# Altium schematic sheet DVT-Levelshifert-HT.SchDoc(DVT-Levelshifert-HT)
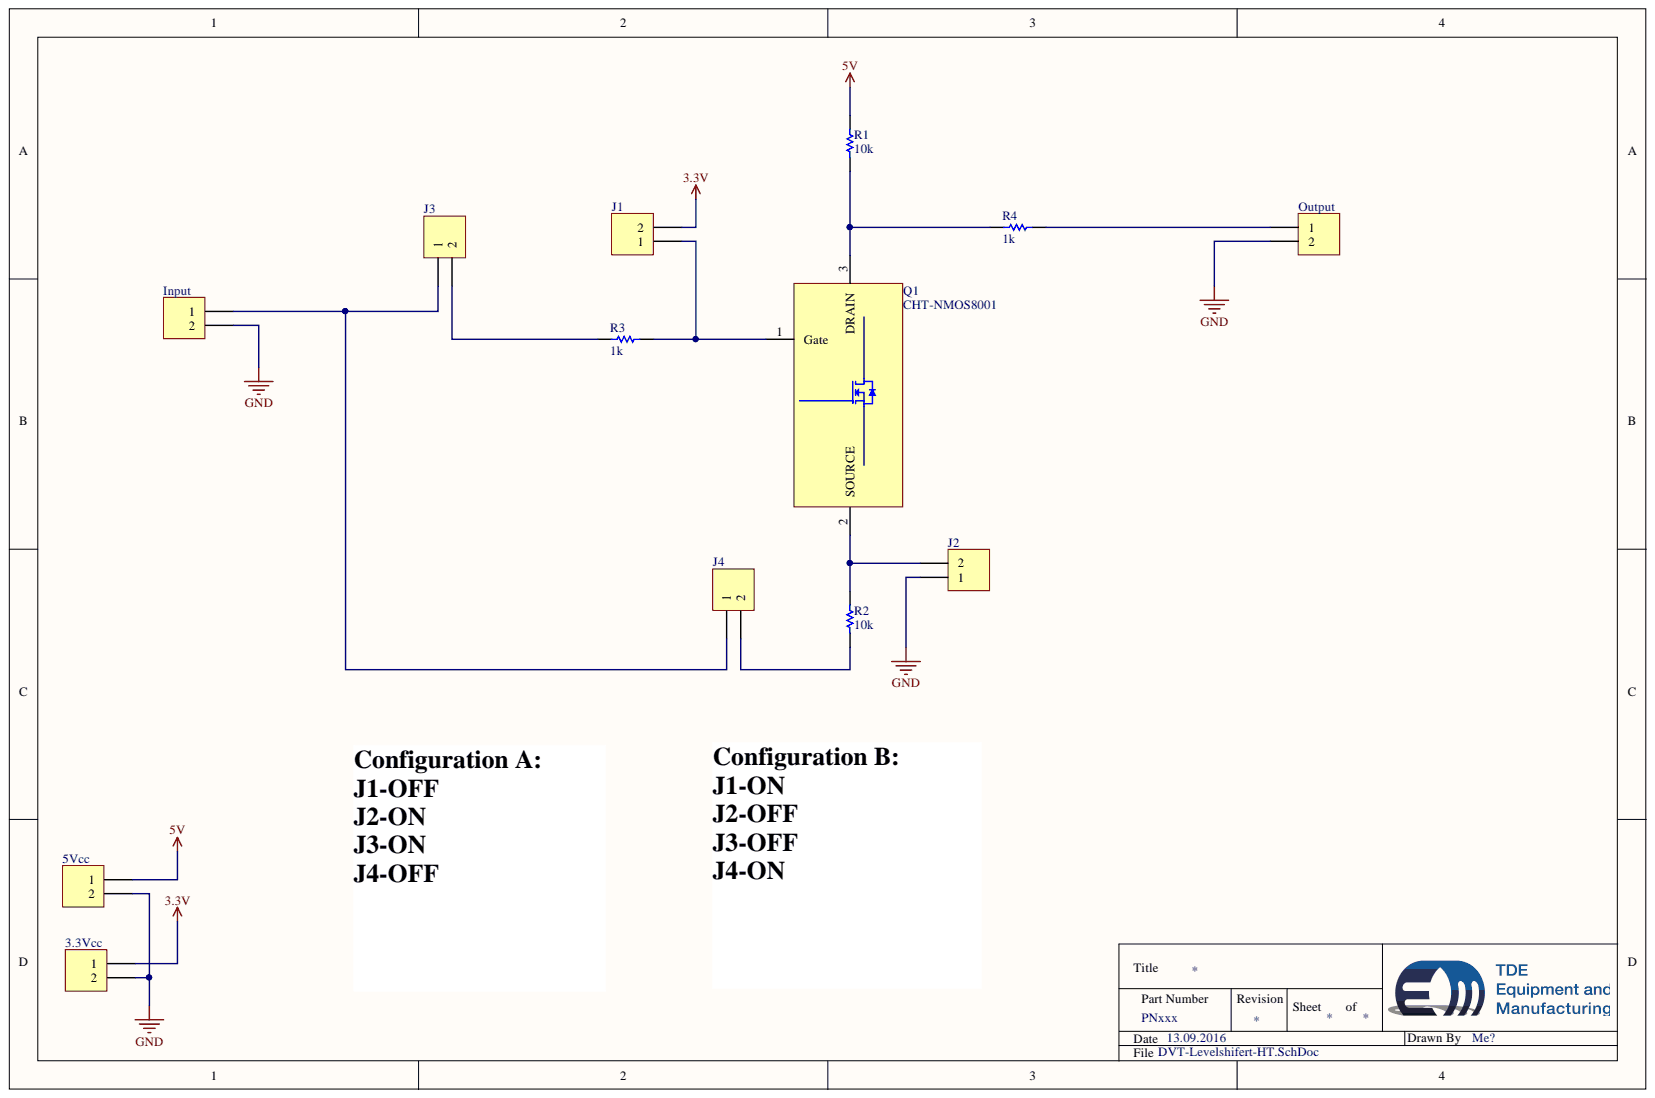
[diagram p0: DVT-Levelshifert-HT.SchDoc]

## Parts
3.3Vcc  PHOENIX_1715721  [Phoenix-2-5_08]  (value not drawn)
5Vcc  PHOENIX_1715721  [Phoenix-2-5_08]  (value not drawn)
Input  PHOENIX_1715721  [Phoenix-2-5_08]  (value not drawn)
J1  PHOENIX_1715721  [HDR1X2]  (value not drawn)
J2  PHOENIX_1715721  [HDR1X2]  (value not drawn)
J3  PHOENIX_1715721  [HDR1X2]  (value not drawn)
J4  PHOENIX_1715721  [HDR1X2]  (value not drawn)
Output  PHOENIX_1715721  [Phoenix-2-5_08]  (value not drawn)
Q1  CHT-NMOS8001  [TDFP16 - NMOS8001]
R1  value 10k  [Dummy-10mm]  (hidden comment: Resistor-Dummy-THT)
R2  value 10k  [Dummy-10mm]  (hidden comment: Resistor-Dummy-THT)
R3  value 1k  [Dummy-10mm]  (hidden comment: Resistor-Dummy-THT)
R4  value 1k  [Dummy-10mm]  (hidden comment: Resistor-Dummy-THT)

## Nets
3.3V: 3.3Vcc.1 J1.2
5V: 5Vcc.1 R1.2
GND: 3.3Vcc.2 5Vcc.2 Input.2 J2.1 Output.2
NetInput_1: Input.1 J3.1 J4.1
NetJ1_1: J1.1 Q1.1 R3.1
NetJ2_2: J2.2 Q1.2 R2.2
NetJ3_2: J3.2 R3.2
NetJ4_2: J4.2 R2.1
NetOutput_1: Output.1 R4.1
NetQ1_3: Q1.3 R1.1 R4.2

## Library data of the parts
3.3Vcc 5Vcc Input Output = 2Pol_1715721: Phoenix, 2 pol, 5.08mm, 22A, 715721; Deliverer PartNr: 193-0564; Deliverer: RS; TDE-PN: CON0008; Component Kind: Standard; LatestRevisionDate: 02.05.2014; LatestRevisionNote: Re-released for DXP Platform.; Library Name: Connectors.IntLib; Manufacturer: Phoenix; Manufacturer PartNr: 1715721; PackageDescription: Phoenix-2-5_08; Price: 0.976/5; Signal Integrity: Connector; Publisher: Sebastian Kulik
J1-J4 = Plug_2_90_2.54: Plug, 2-Pin; Deliverer PartNr: 2356176; Manufacturer: WE; Manufacturer PartNr: 61300211021; Deliverer: Farnell; TDE-PN: CON0010; Component Kind: Standard; LatestRevisionDate: 14.05.2014; LatestRevisionNote: Re-released for DXP Platform.; Library Name: Connectors.IntLib; Signal Integrity: Connector; Publisher: Sebastian Kulik
Q1 = CHT-NMOS8001: Comparator, Hi-Temp, 25MHz; Deliverer: CISSOID; TDE-PN: DIS0052; Component Kind: Standard; Deliverer PartNr: CHT-NMOS8001; Library Name: Discrete.IntLib; Manufacturer: CISSOID; Manufacturer PartNr: CHT-NMOS8001; PackageDescription: DIP16; Price: 204.93/5; Published: 13.09.2016; Publisher: Sebastian Kulik
R1 R2 = Resistor-Dummy-THT: Deliverer PartNr: 148-736; Deliverer: RS; Component Kind: Standard; Description: Resistor-Dummy-THT; Library Name: Resistors.IntLib; Manufacturer: -; Manufacturer PartNr: -; PackageDescription: -; Part Desicription: Resistor-Dummy-THT; Price: 0.027/10; Published: 08.12.2015; Signal Integrity: Res; Simulation: RESISTOR; TDE-PN: -; Publisher: Sebastian Kulik
R3 R4 = Resistor-Dummy-THT: Deliverer PartNr: 148-506; Deliverer: RS; Component Kind: Standard; Description: Resistor-Dummy-THT; Library Name: Resistors.IntLib; Manufacturer: -; Manufacturer PartNr: -; PackageDescription: -; Part Desicription: Resistor-Dummy-THT; Price: 0.027/10; Published: 08.12.2015; Signal Integrity: Res; Simulation: RESISTOR; TDE-PN: -; Publisher: Sebastian Kulik
